# Altium schematic sheet CAN.SchDoc
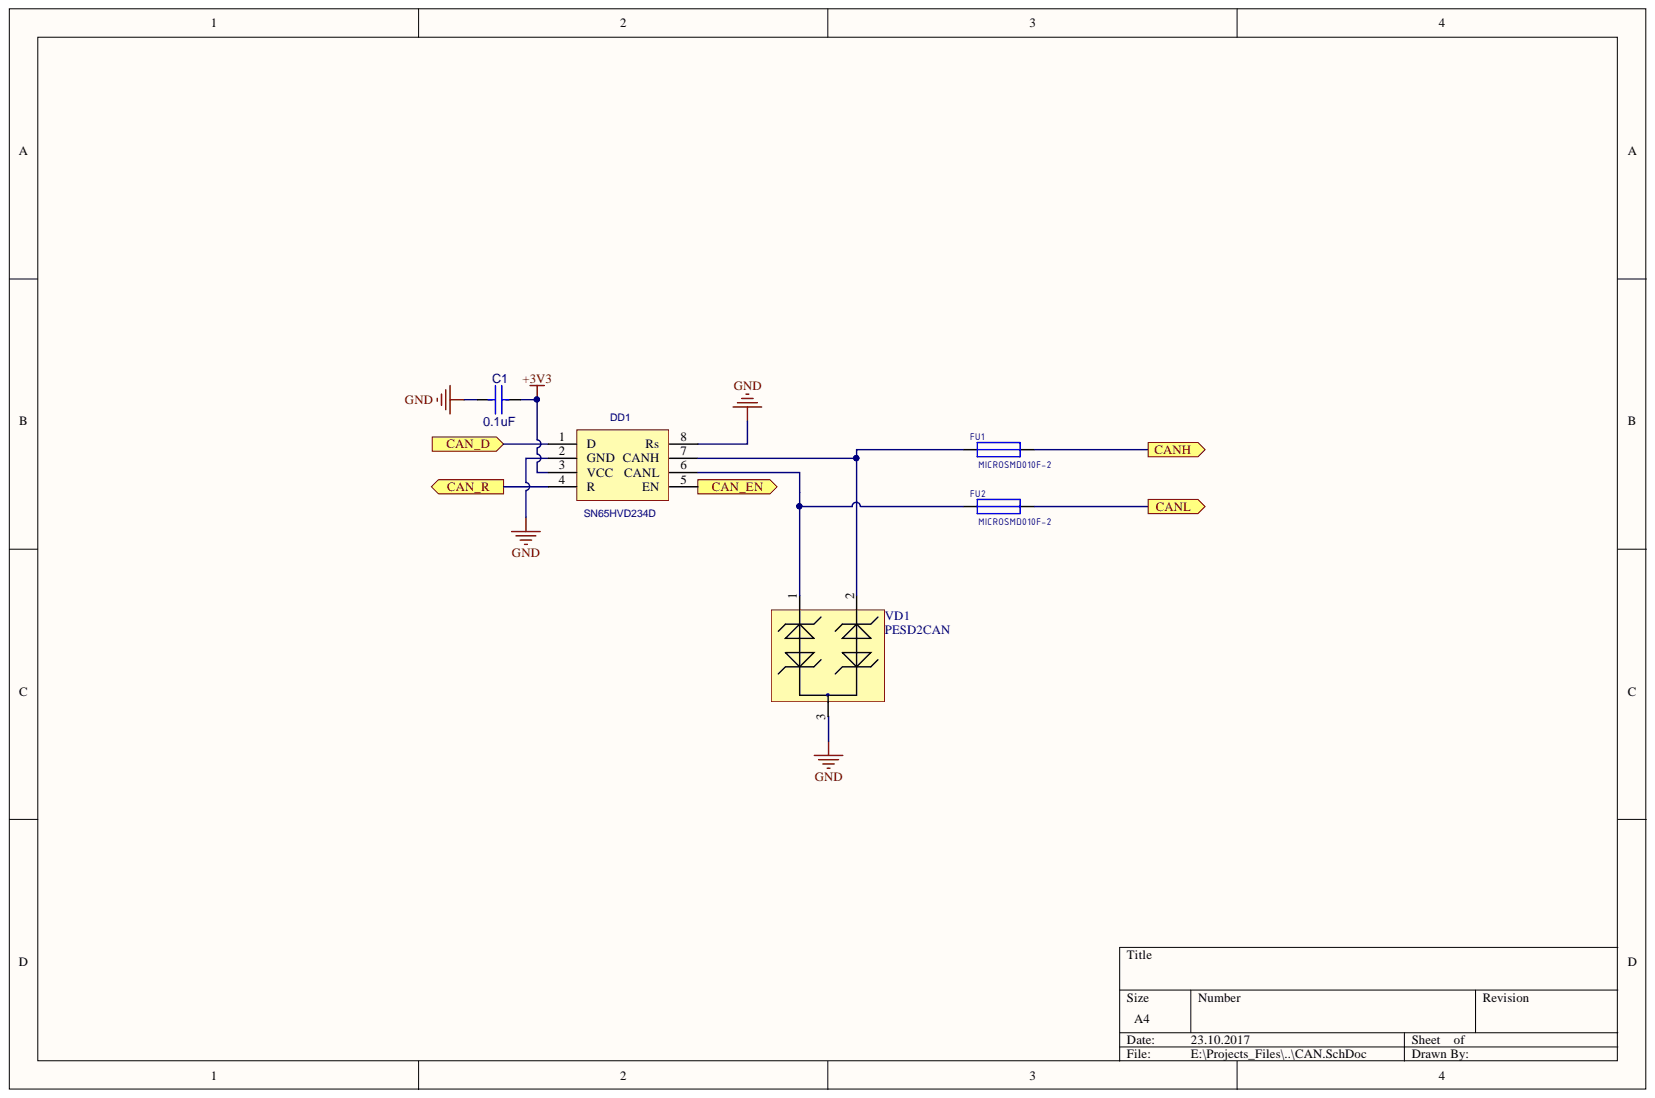
[diagram p0: CAN.SchDoc]

## Parts
C1  0.1uF  [CC0603]
DD1  SN65HVD234D  [SO-8]
FU1  MICROSMD010F-2  [RC1210]
FU2  MICROSMD010F-2  [RC1210]
VD1  PESD2CAN  [SOT-23(123)]

## Ports
CAN_D, CAN_EN, CAN_R, CANH, CANL

## Nets
+3V3: C1.2 DD1.3(VCC)
GND: C1.1 DD1.2(GND) DD1.8(Rs) VD1.3
CAN_D: DD1.1(D) ; port CAN_D
CAN_EN: DD1.5(EN) ; port CAN_EN
CAN_R: DD1.4(R) ; port CAN_R
CANH: FU1.2 ; port CANH
CANL: FU2.2 ; port CANL
NetDD1_6: DD1.6(CANL) FU2.1 VD1.1
NetDD1_7: DD1.7(CANH) FU1.1 VD1.2

## Library data of the parts
C1 = CC0603: Component Kind: Standard; Description: CC0603; Value: 0.1uF; Library Name: gprs_modem_2.SchLib
DD1 = SN65HVD234D: CAN Transceiver; Component Kind: Standard; Library Name: gprs_modem_2.SchLib
FU1 FU2 = MICROSMD: Component Kind: Standard; Description: MICROSMD; Value: MICROSMD010F-2; Library Name: gprs_modem_2.SchLib
VD1 = PESD2CAN: TVS for CAN; Component Kind: Standard; Library Name: gprs_modem_2.SchLib
